# Altium schematic sheet Sheet2.SchDoc
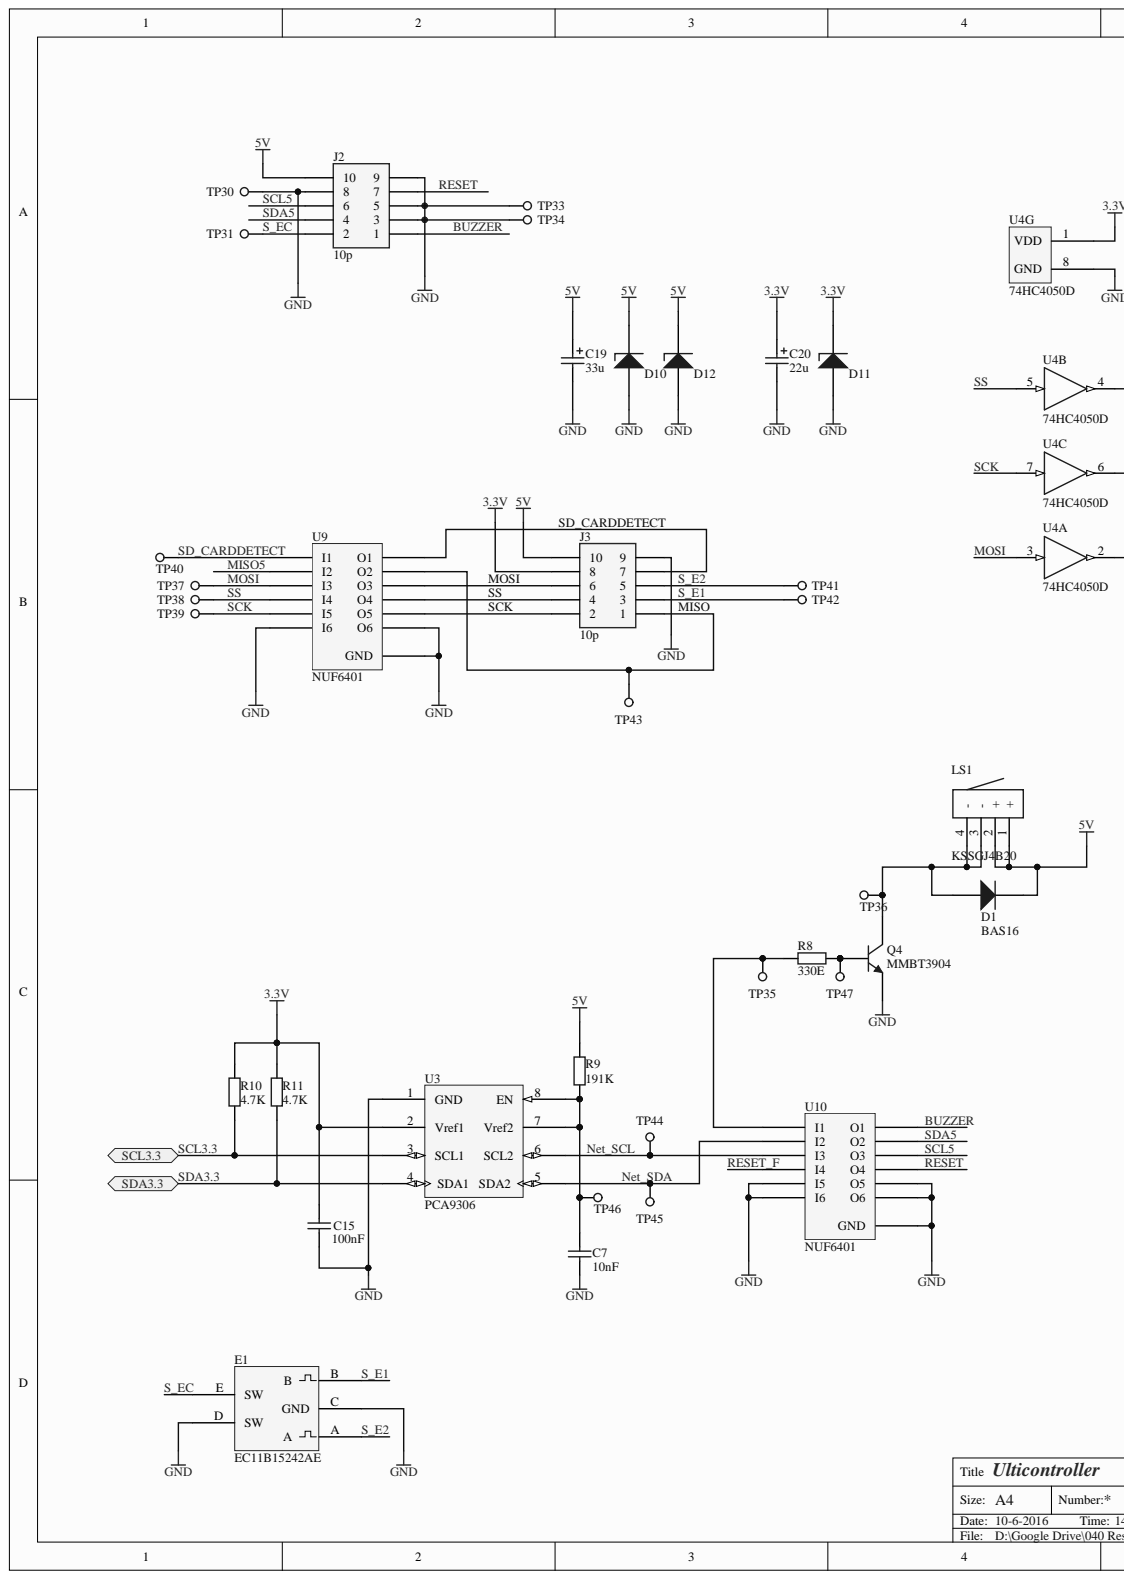
[diagram p0: Sheet2.SchDoc, left]
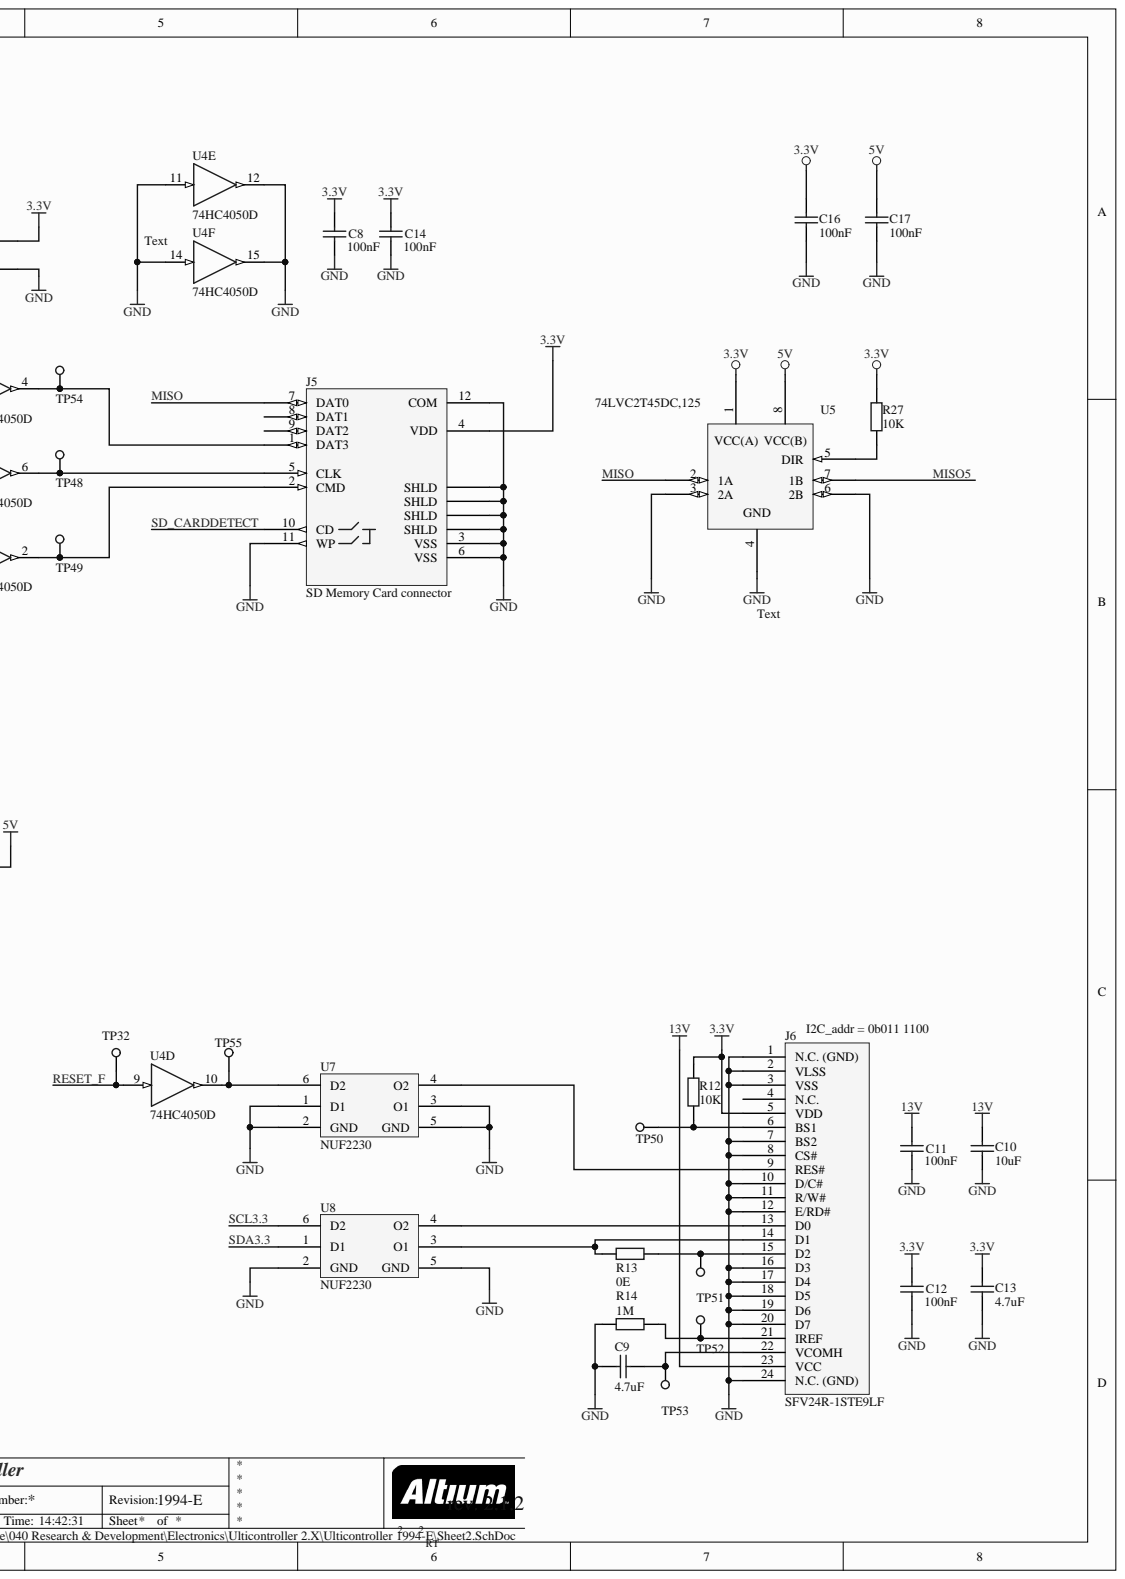
[diagram p1: Sheet2.SchDoc, right]

## Parts
C7  10nF  [C0805 (2012)]
C8  100nF  [C0805 (2012)]
C9  4.7uF  [C1206 (3216)]
C10  10uF  [C1206 (3216)]
C11  100nF  [C0805 (2012)]
C12  100nF  [C0805 (2012)]
C13  4.7uF  [C1206 (3216)]
C14  100nF  [C0805 (2012)]
C15  100nF  [C0805 (2012)]
C16  100nF  [C0805 (2012)]
C17  100nF  [C0805 (2012)]
C19  33u  [CAPAE5543X61M]
C20  22u  [CAPAE5543X61M]
D1  BAS16  [IC SOT23]
D10  ESD9X5.0ST5G  [SODFL100X40-2N]  (value not drawn)
D11  ESD9X3.3ST5G  [SODFL100X40-2N]  (value not drawn)
D12  ESD9X5.0ST5G  [SODFL100X40-2N]  (value not drawn)
E1  EC11B15242AE  [Misc EC11B15242AE]
J2  10p  [HEADER 10P right angle]
J3  10p  [HEADER 10P right angle]
J5  SD Memory Card connector  [CON SD-Card]
J6  SFV24R-1STE9LF  [Con SFV24R-1STE9LF]
LS1  KSSGJ4B20  [Buzzer KSSGJ4B20]
Q4  MMBT3904  [IC SOT23]
R8  330E  [R0805 (2012)]
R9  191K  [R0805 (2012)]
R10  4.7K  [R0805 (2012)]
R11  4.7K  [R0805 (2012)]
R12  10K  [R0805 (2012)]
R13  0E  [R0805 (2012)]
R14  1M  [R0805 (2012)]
R27  10K  [R0805 (2012)]
TP30  2mm  [TESTPOINT 2mm]  (value not drawn)  (no BOM)
TP31  2mm  [TESTPOINT 2mm]  (value not drawn)  (no BOM)
TP32  2mm  [TESTPOINT 2mm]  (value not drawn)  (no BOM)
TP33  2mm  [TESTPOINT 2mm]  (value not drawn)  (no BOM)
TP34  2mm  [TESTPOINT 2mm]  (value not drawn)  (no BOM)
TP35  2mm  [TESTPOINT 2mm]  (value not drawn)  (no BOM)
TP36  2mm  [TESTPOINT 2mm]  (value not drawn)  (no BOM)
TP37  2mm  [TESTPOINT 2mm]  (value not drawn)  (no BOM)
TP38  2mm  [TESTPOINT 2mm]  (value not drawn)  (no BOM)
TP39  2mm  [TESTPOINT 2mm]  (value not drawn)  (no BOM)
TP40  2mm  [TESTPOINT 2mm]  (value not drawn)  (no BOM)
TP41  2mm  [TESTPOINT 2mm]  (value not drawn)  (no BOM)
TP42  2mm  [TESTPOINT 2mm]  (value not drawn)  (no BOM)
TP43  2mm  [TESTPOINT 2mm]  (value not drawn)  (no BOM)
TP44  2mm  [TESTPOINT 2mm]  (value not drawn)  (no BOM)
TP45  2mm  [TESTPOINT 2mm]  (value not drawn)  (no BOM)
TP46  2mm  [TESTPOINT 2mm]  (value not drawn)  (no BOM)
TP47  2mm  [TESTPOINT 2mm]  (value not drawn)  (no BOM)
TP48  2mm  [TESTPOINT 2mm]  (value not drawn)  (no BOM)
TP49  2mm  [TESTPOINT 2mm]  (value not drawn)  (no BOM)
TP50  2mm  [TESTPOINT 2mm]  (value not drawn)  (no BOM)
TP51  2mm  [TESTPOINT 2mm]  (value not drawn)  (no BOM)
TP52  2mm  [TESTPOINT 2mm]  (value not drawn)  (no BOM)
TP53  2mm  [TESTPOINT 2mm]  (value not drawn)  (no BOM)
TP54  2mm  [TESTPOINT 2mm]  (value not drawn)  (no BOM)
TP55  2mm  [TESTPOINT 2mm]  (value not drawn)  (no BOM)
U3  PCA9306  [IC SSOP-8]
U4  74HC4050D  [IC SOIC-16]  (parts A,B,C,D,E,F,G)
U5  74LVC2T45DC,125  [TSSOP50P310X100-8N]
U7  NUF2230  [SOTFL50P160X60-6N]
U8  NUF2230  [SOTFL50P160X60-6N]
U9  NUF6401  [SON50P140X100_HS-13N]
U10  NUF6401  [SON50P140X100_HS-13N]

## Ports
SCL3.3, SDA3.3

## Nets
3.3V: C8.2 C12.2 C13.1 C14.2 C15.2 C16.1 C20.1 D11.1 J3.8 J5.4(VDD) J6.5(VDD) R10.2 R11.2 R12.2 R27.2 U3.2(Vref1) U4.1(VDD) U5.1
5V: C17.1 C19.1 D1.3 D10.1 D12.1 J2.10 J3.10 LS1.1(+) LS1.2(+) R9.2 U5.8
13V: C10.2 C11.2 J6.23(VCC)
GND: C7.1 C8.1 C9.2 C10.1 C11.1 C12.1 C13.2 C14.1 C15.1 C16.2 C17.2 C19.2 C20.2 D10.2 D11.2 D12.2 E1.C(GND) E1.D(SW) J2.3 J2.5 J2.8 J2.9 J3.9 J5.3(VSS) J5.6(VSS) J5.11(WP) J5.12(COM) J5.A(SHLD) J5.B(SHLD) J5.C(SHLD) J5.D(SHLD) J6.1(N.C._(GND)) J6.2(VLSS) J6.3(VSS) J6.7(BS2) J6.8(CS#) J6.10(D/C#) J6.11(R/W#) J6.12(E/RD#) J6.16(D3) J6.17(D4) J6.18(D5) J6.19(D6) J6.20(D7) J6.24(N.C._(GND)) Q4.2 R14.1 TP30.1 TP33.1 TP34.1 U3.1(GND) U4.8(GND) U4.11 U4.12 U4.14 U4.15 U5.3(2A) U5.4 U5.6(2B) U7.1(D1) U7.2(GND) U7.3(O1) U7.5(GND) U8.2(GND) U8.5(GND) U9.6(I6) U9.7(O6) U9.13(GND) U10.5(I5) U10.6(I6) U10.7(O6) U10.8(O5) U10.13(GND)
BUZZER: J2.1 U10.12(O1)
MISO: J5.7(DAT0) U5.2(1A)
MISO5: U5.7(1B) U9.2(I2)
MOSI: TP37.1 U4.3 U9.3(I3)
NET_SCL: TP44.1 U3.6(SCL2) U10.3(I3)
NET_SDA: TP45.1 U3.5(SDA2) U10.2(I2)
RESET: J2.7 U10.9(O4)
RESET_F: TP32.1 U4.9 U10.4(I4)
S_E1: E1.B J3.3 TP42.1
S_E2: E1.A J3.5 TP41.1
S_EC: E1.E(SW) J2.2 TP31.1
SCK: TP39.1 U4.7 U9.5(I5)
SCL3.3: R10.1 U3.3(SCL1) U8.6(D2) ; port SCL3.3
SCL5: J2.6 U10.10(O3)
SD_CARDDETECT: J5.10(CD) TP40.1 U9.1(I1)
SDA3.3: R11.1 U3.4(SDA1) U8.1(D1) ; port SDA3.3
SDA5: J2.4 U10.11(O2)
SS: TP38.1 U4.5 U9.4(I4)
NetC7_2: C7.2 R9.1 TP46.1 U3.7(Vref2) U3.8(EN)
NetC9_1: C9.1 J6.22(VCOMH) TP53.1
NetD1_1: D1.1 LS1.3 LS1.4 Q4.3 TP36.1
NetJ3_1: J3.1 TP43.1 U9.11(O2)
NetJ3_2: J3.2 U9.8(O5)
NetJ3_4: J3.4 U9.9(O4)
NetJ3_6: J3.6 U9.10(O3)
NetJ3_7: J3.7 U9.12(O1)
NetJ5_1: J5.1(DAT3) TP54.1 U4.4
NetJ5_2: J5.2(CMD) TP49.1 U4.2
NetJ5_5: J5.5(CLK) TP48.1 U4.6
NetJ6_6: J6.6(BS1) R12.1 TP50.1
NetJ6_9: J6.9(RES#) U7.4(O2)
NetJ6_13: J6.13(D0) U8.4(O2)
NetJ6_14: J6.14(D1) R13.1 U8.3(O1)
NetJ6_15: J6.15(D2) R13.2 TP51.1
NetJ6_21: J6.21(IREF) R14.2 TP52.1
NetQ4_1: Q4.1 R8.2 TP47.1
NetR8_1: R8.1 TP35.1 U10.1(I1)
NetR27_1: R27.1 U5.5(DIR)
NetTP55_1: TP55.1 U4.10 U7.6(D2)

Not connected: J5 8(DAT1) 9(DAT2); J6 4(N.C.)

## Library data of the parts
C7 = Cap 10nF X7R 0805: Cap 10nF X7R 0805 10% 100V; Mfg: AVX; Mfg code: 08051C103K4Z2A; Component Kind: Standard; Faber ItemCode: -; Max soldering temp: -; Remarks: -; SMD: Yes; Supplier: Farnell; Supplier code: 1833850; Value: 10nF; Library Name: Faber_SCH_lib.SchLib
C8 C11 C12 C14-C17 = Cap 100nF X7R 0805: Cap 100nF X7R 0805 10% 100V; Mfg: AVX; Mfg code: 08051C104K4T2A; Component Kind: Standard; Faber ItemCode: -; Max soldering temp: -; Remarks: -; SMD: Yes; Supplier: Farnell; Supplier code: 1833888; Value: 100nF; Library Name: Faber_SCH_lib.SchLib
C9 C13 = Cap 4.7uF X7R 1206: Cap 4.7uF 1206 X7R 10% 25V; Mfg: TDK; Mfg code: C3216X7R1E475K160AC; Component Kind: Standard; Faber ItemCode: -; Max soldering temp: -; Remarks: -; SMD: Yes; Supplier: Farnell; Supplier code: 1907356; Value: 4.7uF; Library Name: Faber_SCH_lib.SchLib
C10 = Cap 10uF X7R 1206: Cap 10uF 1206 X7R 10% 25V; Mfg: KEMET; Mfg code: C1206C106K3RAC TU; Component Kind: Standard; Faber ItemCode: -; Max soldering temp: -; Remarks: -; SMD: Yes; Supplier: Farnell; Supplier code: 2118134; Value: 10uF; Library Name: Faber_SCH_lib.SchLib
C19 = Cap 33uF: CAP ALUM 33UF 20% 10V SMD; Capacitance: 33µF; Impedance: 1.35 Ohm; Lifetime @ Temp.: 2000 Hrs @ 105°C; Mfg: Panasonic Electronic Components; Mfg code: EEE-FK1A330UR; Polarization: Polar; Ripple Current: 67.5mA @ 120Hz; Surface Mount Land Size: 0.169" L x 0.169" W (4.30mm x 4.30mm); Applications: General Purpose; Category: Capacitors; Component Kind: Standard; ComponentLink1Description: http://industrial.panasonic.com/www-cgi/jvcr13pz.cgi?E+PZ+3+ABA0120+EEEFK1A330UR+7+WW; ComponentLink1URL: http://industrial.panasonic.com/www-cgi/jvcr13pz.cgi?E+PZ+3+ABA0120+EEEFK1A330UR+7+WW; ESR (Equivalent Series Resistance): -; Height - Seated (Max): 0.228" (5.80mm); Lead Spacing: -; Mounting Type: Surface Mount; Operating Temperature: -55°C ~ 105°C; Package / Case: Radial, Can - SMD; Packaging: Tape & Reel (TR); Pricing: 2000=0.07952, 4000=0.0751, 10000=0.07068, 14000=0.06847, 50000=0.06185, 100000=0.05743 (EUR); RoHS: RoHS Compliant; Size / Dimension: 0.157" Dia (4.00mm); Stock: 18000; Supplier 1: Digi-Key; Supplier Part Number 1: PCE3778TR-ND; Tolerance: ±20%; Voltage Rating: 10V; Library Name: UltiController.SCHLIB
C20 = Cap 22uF: CAP ALUM 22UF 20% 6.3V SMD; Capacitance: 22µF; Lifetime @ Temp.: 2000 Hrs @ 105°C; Mfg: Panasonic Electronic Components; Mfg code: EEV-HB0J220R; Polarization: Polar; Ripple Current: 26mA @ 120Hz; Surface Mount Land Size: 0.169" L x 0.169" W (4.30mm x 4.30mm); Applications: General Purpose; Category: Capacitors; Component Kind: Standard; ComponentLink1Description: http://media.digikey.com/pdf/Data%20Sheets/Panasonic%20Capacitors%20PDFs/EEV-HB%20(HB%20Series).pdf; ComponentLink1URL: http://media.digikey.com/pdf/Data%20Sheets/Panasonic%20Capacitors%20PDFs/EEV-HB%20(HB%20Series).pdf; ESR (Equivalent Series Resistance): -; Height - Seated (Max): 0.228" (5.80mm); Impedance: -; Lead Spacing: -; Mounting Type: Surface Mount; Operating Temperature: -40°C ~ 105°C; Package / Case: Radial, Can - SMD; Packaging: Tape & Reel (TR); Pricing: 2000=0.06791, 4000=0.06413, 10000=0.06036 (EUR); RoHS: RoHS non-compliant; Size / Dimension: 0.157" Dia (4.00mm); Stock: 10000; Supplier 1: Digi-Key; Supplier Part Number 1: PCE3026TR-ND; Tolerance: ±20%; Voltage Rating: 6.3V; Library Name: UltiController.SCHLIB
D1 = Diode BAS16: Diode BAS16  SOT23 85V 215MA; Mfg: NXP; Component Kind: Standard; Faber ItemCode: -; Max soldering temp: -; Mfg code: BAS16; Remarks: -; SMD: Yes; Supplier: Farnell; Supplier code: 1081180; Value: -; Library Name: Faber_SCH_lib.SchLib
D10 D12 = ESD9X5.0ST5G: TVS DIODE 5VWM 12.3VC SOD923; Capacitance @ Frequency: 65pF @ 1MHz; Current - Peak Pulse (10/1000µs): 8.7A (8/20µs); Mfg: ON Semiconductor; Power - Peak Pulse: 107W; Power Line Protection: No; Type: Zener; Unidirectional Channels: 1; Voltage - Breakdown (Min): 6.2V; Voltage - Clamping (Max) @ Ipp: 12.3V; Voltage - Reverse Standoff (Typ): 5V (Max); Applications: General Purpose; Bidirectional Channels: -; Category: Circuit Protection; Component Kind: Standard; ComponentLink1Description: http://www.onsemi.com/pub_link/Collateral/ESD9X3.3ST5G-D.PDF; ComponentLink1URL: http://www.onsemi.com/pub_link/Collateral/ESD9X3.3ST5G-D.PDF; Mfg code: ESD9X5.0ST5G; Mounting Type: Surface Mount; Operating Temperature: -55°C ~ 150°C (TJ); Package / Case: SOD-923; Packaging: Tape & Reel (TR); Pricing: 8000=0.02337, 16000=0.02032, 24000=0.01829, 56000=0.01626, 200000=0.01351 (EUR); RoHS: RoHS Compliant; Stock: 40000; Supplier 1: Digi-Key; Supplier Device Package: SOD-923; Supplier Part Number 1: ESD9X5.0ST5GOSTR-ND; Library Name: Olimex interface board.SCHLIB
D11 = ESD9X3.3ST5G: TVS DIODE 3.3VWM 10.4VC SOD923; Capacitance @ Frequency: 80pF @ 1MHz; Current - Peak Pulse (10/1000µs): 9.8A (8/20µs); Mfg: ON Semiconductor; Power - Peak Pulse: 102W; Power Line Protection: No; Type: Zener; Unidirectional Channels: 1; Voltage - Breakdown (Min): 5V; Voltage - Clamping (Max) @ Ipp: 10.4V; Voltage - Reverse Standoff (Typ): 3.3V (Max); Applications: Automotive; Bidirectional Channels: -; Category: Circuit Protection; Component Kind: Standard; ComponentLink1Description: http://www.onsemi.com/pub_link/Collateral/ESD9X3.3ST5G-D.PDF; ComponentLink1URL: http://www.onsemi.com/pub_link/Collateral/ESD9X3.3ST5G-D.PDF; Mfg code: ESD9X3.3ST5G; Mounting Type: Surface Mount; Operating Temperature: -55°C ~ 150°C (TJ); Package / Case: SOD-923; Packaging: Tape & Reel (TR); Pricing: 8000=0.03287, 16000=0.02794, 24000=0.02629, 56000=0.02465, 200000=0.02136 (EUR); RoHS: RoHS Compliant; Stock: 136000; Supplier 1: Digi-Key; Supplier Device Package: SOD-923; Supplier Part Number 1: ESD9X3.3ST5GOSTR-ND; Library Name: Olimex interface board.SCHLIB
E1 = Misc EC11B15242AE: Misc EC11B15242AE encoder horiz; Mfg: ALPS; Component Kind: Standard; Faber ItemCode: -; Max soldering temp: -; Mfg code: EC11B15242AE; Remarks: -; SMD: No; Supplier: Farnell; Supplier code: 2064978; Value: -; Library Name: Faber_SCH_lib.SchLib
J2 J3 = (no symbol name): Header 2x5p right angle 2.54mm; Mfg: WURTH ELEKTRONIK; Mfg code: 61201021721; Component Kind: Standard; Faber ItemCode: -; Max soldering temp: -; Remarks: -; SMD: No; Supplier: Farnell; Supplier code: 1642023; Value: -; Library Name: Faber_SCH_lib.SchLib
J5 = (no symbol name): Connector Std,reverse SD Memory Card connector; Bestel grote: 1; Faber Artikelomschrijving: SD-KAARDHOUDER SMD DM1AA-SF-PEJ(72) HIROSE; Faber ItemCode: 36966100; Mfg: HRS (HIROSE); Mfg code: DM1AA-SF-PEJ(72); Prijs: 3,02; Component Kind: Standard; Library Name: Faber_SCH_lib.SchLib; Max soldering temp: -; Remarks: -; SMD: Yes; Supplier: Mouser; Supplier code: 798-DM1AA-SF-PEJ72; Value: -
J6 = Connector SFV24R-1STE9LF: Connector FPC/FFC 0.5mm R/A 24p SMD; Mfg: FCI; Component Kind: Standard; Faber ItemCode: -; Max soldering temp: -; Mfg code: SFV24R-1STE9LF; Remarks: -; SMD: Yes; Supplier: Farnell; Supplier code: 1830598; Value: -; Library Name: Faber_SCH_lib.SchLib
LS1 = Buzzer KSSGJ4B20: Buzzer KSSGJ4B20 SMD; Mfg: KINGSTATE; Component Kind: Standard; Faber ItemCode: -; Max soldering temp: -; Mfg code: KSSGJ4B20; Remarks: -; SMD: Yes; Supplier: Farnell; Supplier code: 1502710; Value: -; Library Name: Faber_SCH_lib.SchLib
Q4 = NPN MMBT3904: NPN MMBT3904 SOT-23 200mA; Mfg: NXP; Mfg code: MMBT3904,215; Component Kind: Standard; Faber ItemCode: -; Max soldering temp: -; Remarks: -; SMD: Yes; Supplier: Farnell; Supplier code: 1757935; Value: -; Library Name: Faber_SCH_lib.SchLib
R8 = Res 330E 0805: Res 330E 0805 1% 100mW; Mfg: MULTICOMP; Mfg code: MC 0.1W 0805 1% 330R; Component Kind: Standard; Faber ItemCode: -; Max soldering temp: -; Remarks: -; SMD: Yes; Supplier: Farnell; Supplier code: 9333037; Value: 330E; Library Name: Faber_SCH_lib.SchLib
R9 = Res 191K 0805: Res 191K 0805 1% 125mW; Mfg: VISHAY DRALORIC; Mfg code: CRCW0805191KFKEA; Component Kind: Standard; Faber ItemCode: -; Max soldering temp: -; Remarks: -; SMD: Yes; Supplier: Farnell; Supplier code: 2139049; Value: 191K; Library Name: Faber_SCH_lib.SchLib
R10 R11 = Res 4.7K 0805: Res 4.7K 0805 1% 100mW; Mfg: MULTICOMP; Mfg code: MC 0.1W 0805 1% 4K7; Component Kind: Standard; Faber ItemCode: -; Max soldering temp: -; Remarks: -; SMD: Yes; Supplier: Farnell; Supplier code: 9333266; Value: 4.7K; Library Name: Faber_SCH_lib.SchLib
R12 R27 = Res 10K 0805: Res 10K 0805 1% 100mW; Mfg: MULTICOMP; Mfg code: MC 0.1W 0805 1% 10K; Component Kind: Standard; Faber ItemCode: -; Max soldering temp: -; Remarks: -; SMD: Yes; Supplier: Farnell; Supplier code: 9332391; Value: 10K; Library Name: Faber_SCH_lib.SchLib
R13 = Res 0E 0805: Res 0E 0805 1% 100mW; Mfg: MULTICOMP; Mfg code: MC 0.1W 0805 0R; Component Kind: Standard; Faber ItemCode: -; Max soldering temp: -; Remarks: -; SMD: Yes; Supplier: Farnell; Supplier code: 9333681; Value: 0E; Library Name: Faber_SCH_lib.SchLib
R14 = Res 1M 0805: Res 1M 0805 1% 100mW; Mfg: MULTICOMP; Mfg code: MC 0.1W 0805 1% 1M; Component Kind: Standard; Faber ItemCode: -; Max soldering temp: -; Remarks: -; SMD: Yes; Supplier: Farnell; Supplier code: 9332413; Value: 1M; Library Name: Faber_SCH_lib.SchLib
TP30-TP55 = (no symbol name): Testpoint, 2mm, round; Remarks: Not a component; Faber ItemCode: -; Max soldering temp: -; Mfg: -; Mfg code: -; Supplier: -; Supplier code: -; Value: -; Library Name: Faber_SCH_lib.SchLib
U3 = IC PCA9306: IC PCA9306 Voltage Level translator; Mfg: TEXAS INSTRUMENTS; Mfg code: PCA9306DCTR; Component Kind: Standard; Faber ItemCode: -; Max soldering temp: -; Remarks: -; SMD: Yes; Supplier: Farnell; Supplier code: 1470936; Value: -; Library Name: Faber_SCH_lib.SchLib
U4 = IC 74HC4050D: IC Hex Non-Inverting Buffer/Logic-Level Down Converter; Bestel grote: 2500; Faber Artikelomschrijving: IC 74HC4050D SO-16 NXP; Faber ItemCode: 35592900; Mfg: NXP; Prijs: 0,0878; Component Kind: Standard; Library Name: Faber_SCH_lib.SchLib; Max soldering temp: -; Mfg code: 74HC4050D; Remarks: -; SMD: Yes; Supplier: Arrow CE GmbH; Supplier code: 74HC4050D,653 (T&R); Value: -
U5 = 74LVC2T45DC,125: 2-bit translaing transceiver; Mfg: NXP; Component Kind: Standard; Mfg code: 74LVC2T45DC,125; Supplier: Farnell; Supplier code: 2444915; Library Name: Olimex interface board.SchLib
U7 U8 = NUF2230: Attenuation Value: 30dB @ 800MHz ~ 900MHz; Center / Cutoff Frequency: 125MHz (Cutoff); ESD Protection: Yes; Filter Order: 2nd; Mfg: ON Semiconductor; Mfg code: NUF2230XV6T1G; Number of Channels: 2; Resistance - Channel (Ohms): 100; Values: R = 100 Ohms, C = 16pF; Applications: Data Lines for Mobile Devices; Category: Filters; Component Kind: Standard; ComponentLink1Description: http://www.onsemi.com/pub_link/Collateral/NUF2230XV6-D.PDF; ComponentLink1URL: http://www.onsemi.com/pub_link/Collateral/NUF2230XV6-D.PDF; Current: -; Height: 0.024" (0.60mm); Operating Temperature: -40°C ~ 85°C; Package / Case: SOT-563, SOT-666; Packaging: Cut Tape (CT); Pricing: 1=0.27, 10=0.252, 25=0.2404, 50=0.2326, 100=0.2248, 250=0.21316, 500=0.17828, 1000=0.13836 (EUR); RoHS: RoHS Compliant; Size / Dimension: 0.063" L x 0.047" W (1.60mm x 1.20mm); Stock: 3048; Supplier 1: Digi-Key; Supplier Part Number 1: NUF2230XV6T1GOSCT-ND; Technology: RC (Pi); Type: Low Pass; Library Name: printehead.SCHLIB
U9 U10 = NUF6401: Attenuation Value: 30dB @ 800MHz ~ 3GHz; Center / Cutoff Frequency: 110MHz (Cutoff); ESD Protection: Yes; Filter Order: 2nd; Mfg: ON Semiconductor; Mfg code: NUF6401MNT1G; Number of Channels: 6; Resistance - Channel (Ohms): 100; Values: R = 100 Ohms, C = 17pF; Applications: Data Lines for Mobile Devices; Category: Filters; Component Kind: Standard; ComponentLink1Description: http://www.onsemi.cn/pub_link/Collateral/NUF6401-D.PDF; ComponentLink1URL: http://www.onsemi.cn/pub_link/Collateral/NUF6401-D.PDF; Current: -; Height: 0.039" (1.00mm); Operating Temperature: -40°C ~ 85°C; Package / Case: 12-VFDFN Exposed Pad; Packaging: Tape & Reel (TR); Pricing: 3000=0.13931, 6000=0.13111, 15000=0.12292, 30000=0.11472 (EUR); RoHS: RoHS Compliant; Size / Dimension: 0.118" L x 0.053" W (3.00mm x 1.35mm); Stock: 6000; Supplier 1: Digi-Key; Supplier Part Number 1: NUF6401MNT1GOSTR-ND; Technology: RC (Pi); Type: Low Pass; Library Name: UltiController.SCHLIB
